# Altium schematic sheet superware_V1.0.SchDoc
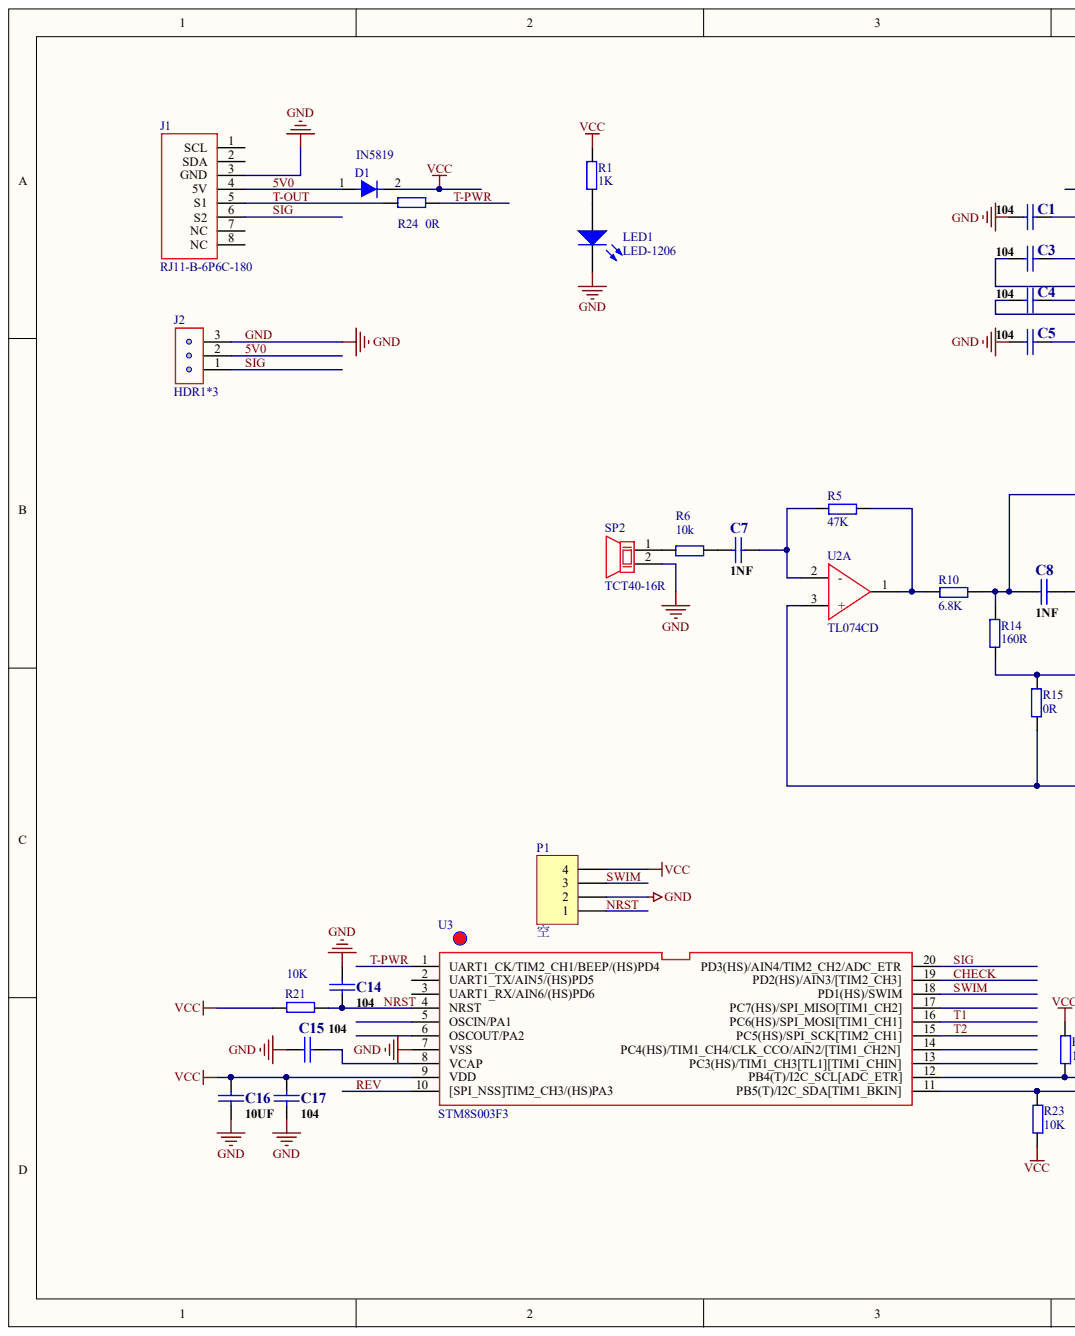
[diagram p0: superware_V1.0.SchDoc, left]
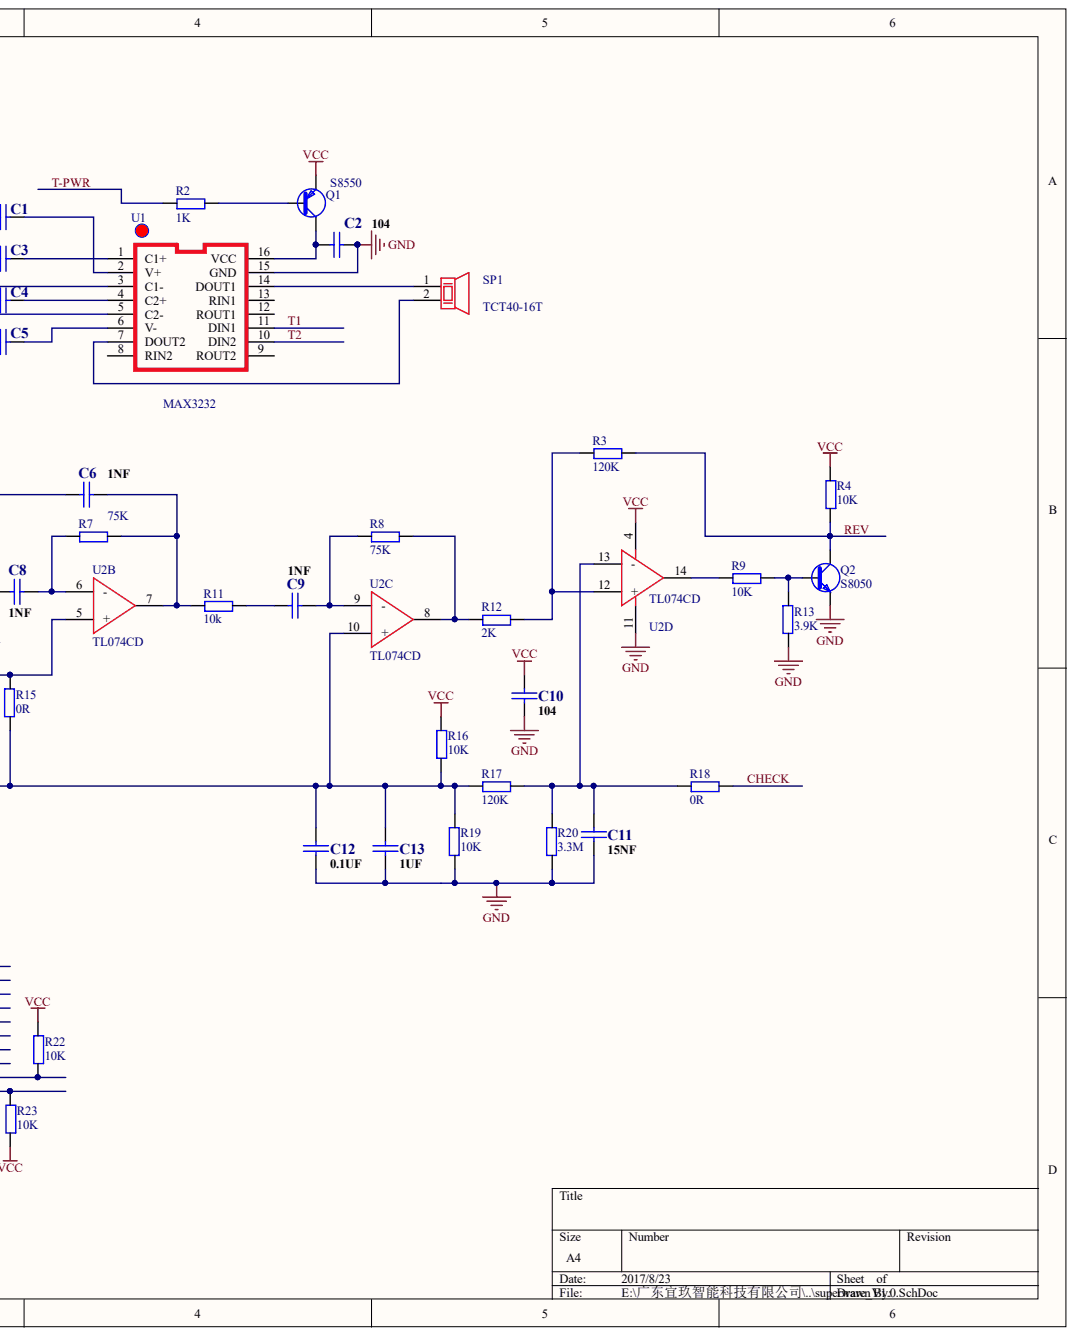
[diagram p1: superware_V1.0.SchDoc, right]

## Parts
C1  104  [0805]
C2  104  [0805]
C3  104  [0805]
C4  104  [0805]
C5  104  [0805]
C6  1NF  [0805]
C7  1NF  [0805]
C8  1NF  [0805]
C9  1NF  [0805]
C10  104  [0805]
C11  15NF  [0805]
C12  0.1UF  [0805]
C13  1UF  [0805]
C14  104  [0805]
C15  104  [0805]
C16  10UF  [0805]
C17  104  [0805]
D1  IN5819  [DO-214AC]
J1  RJ11-B-6P6C-180  [RJ11-B_6P6C_180]
J2  HDR1*3  [HDR1X3]
LED1  LED-1206  [LED-1206]
P1  空  [SMB1X4]
Q1  S8550  [SOT-23]
Q2  S8050  [SOT-23]
R1  1K  [0805]
R2  1K  [0805]
R3  120K  [0805]
R4  10K  [0805]
R5  47K  [0805]
R6  10k  [0805]
R7  75K  [0805]
R8  75K  [0805]
R9  10K  [0805]
R10  6.8K  [0805]
R11  10k  [0805]
R12  2K  [0805]
R13  3.9K  [0805]
R14  160R  [0805]
R15  0R  [0805]
R16  10K  [0805]
R17  120K  [0805]
R18  0R  [0805]
R19  10K  [0805]
R20  3.3M  [0805]
R21  10K  [0805]
R22  10K  [0805]
R23  10K  [0805]
R24  0R  [0805]
SP1  TCT40-16T  [TCT40-16R]
SP2  TCT40-16R  [TCT40-16R]
U1  MAX3232  [SOP-16]
U2  TL074CD  [SOP-14]  (parts A,B,C,D)
U3  STM8S003F3  [TSSOP20]

## Nets
VCC: C10.1 C16.1 C17.1 D1.2 P1.4 Q1.2 R1.1 R4.2 R16.2 R21.2 R22.2 R23.1 U2.4 U3.9(VDD)
5V0: D1.1 J1.4(5V) J2.2
CHECK: R18.2 U3.19(PD2(HS)/AIN3/[TIM2_CH3])
GND: C1.2 C2.2 C5.2 C10.2 C11.2 C12.2 C13.2 C14.2 C15.2 C16.2 C17.2 J1.3(GND) J2.3 LED1.2 P1.2 Q2.2 R13.2 R19.2 R20.2 SP2.2 U1.15(GND) U2.11(+) U3.7(VSS)
NRST: C14.1 P1.1 R21.1 U3.4(NRST)
REV: Q2.3 R3.2 R4.1 U3.10([SPI_NSS]TIM2_CH3/(HS)PA3)
SIG: J1.6(S2) J2.1 U3.20(PD3(HS)/AIN4/TIM2_CH2/ADC_ETR)
SWIM: P1.3 U3.18(PD1(HS)/SWIM)
T1: U1.11(DIN1) U3.16(PC6(HS)/SPI_MOSI[TIM1_CH1])
T2: U1.10(DIN2) U3.15(PC5(HS)/SPI_SCK[TIM2_CH1])
T-OUT: J1.5(S1) R24.2
T-PWR: R2.1 R24.1 U3.1(UART1_CK/TIM2_CH1/BEEP/(HS)PD4)
NetC1_1: C1.1 U1.2(V+)
NetC2_1: C2.1 Q1.3 U1.16(VCC)
NetC3_1: C3.1 U1.1(C1+)
NetC3_2: C3.2 U1.3(C1-)
NetC4_1: C4.1 U1.4(C2+)
NetC4_2: C4.2 U1.5(C2-)
NetC5_1: C5.1 U1.6(V-)
NetC6_1: C6.1 R7.2 R11.1 U2.7
NetC6_2: C6.2 C8.1 R10.2 R14.1
NetC7_1: C7.1 R6.2
NetC7_2: C7.2 R5.1 U2.2(-)
NetC8_2: C8.2 R7.1 U2.6(-)
NetC9_1: C9.1 R11.2
NetC9_2: C9.2 R8.1 U2.9(-)
NetC11_1: C11.1 R17.2 R18.1 R20.1 U2.13(-)
NetC12_1: C12.1 C13.1 R15.2 R16.1 R17.1 R19.1 U2.3(+) U2.10(+)
NetC15_1: C15.1 U3.8(VCAP)
NetLED1_1: LED1.1 R1.2
NetQ1_1: Q1.1 R2.2
NetQ2_1: Q2.1 R9.2 R13.1
NetR3_1: R3.1 R12.2 U2.12
NetR5_2: R5.2 R10.1 U2.1
NetR6_1: R6.1 SP2.1
NetR8_2: R8.2 R12.1 U2.8
NetR9_1: R9.1 U2.14
NetR14_2: R14.2 R15.1 U2.5(+)
NetR22_1: R22.1 U3.12(PB4(T)/I2C_SCL[ADC_ETR])
NetR23_2: R23.2 U3.11(PB5(T)/I2C_SDA[TIM1_BKIN])
NetSP1_1: SP1.1 U1.14(DOUT1)
NetSP1_2: SP1.2 U1.7(DOUT2)

Not connected: J1 1(SCL) 2(SDA) 7(NC) 8(NC); U1 8(RIN2) 9(ROUT2) 12(ROUT1) 13(RIN1); U3 2(UART1_TX/AIN5/(HS)PD5) 3(UART1_RX/AIN6/(HS)PD6) 5(OSCIN/PA1) 6(OSCOUT/PA2) 13(PC3(HS)/TIM1_CH3[TL1][TIM1_CHIN]) 14(PC4(HS)/TIM1_CH4/CLK_CCO/AIN2/[TIM1_CH2N]) 17(PC7(HS)/SPI_MISO[TIM1_CH2])

## Library data of the parts
C1-C17 = CAP: Capacitor; Component Kind: Standard; Library Name: DQSCH.SCHLIB
P1 = Header 4: Header, 4-Pin; Component Kind: Standard; LatestRevisionDate: 17-Jul-2002; LatestRevisionNote: Re-released for DXP Platform.; Publisher: Altium Limited
Q1 = PNP: Component Kind: Standard; Library Name: DQSCH.SCHLIB
Q2 = NPN: Component Kind: Standard; Library Name: DQSCH.SCHLIB
R1-R24 = R 0805: Component Kind: Standard; Library Name: DQSCH.SCHLIB
SP1 = TCT40-16R: Component Kind: Standard; Library Name: DQSCH.SCHLIB
U2 = LM324: Component Kind: Standard; Library Name: DQSCH.SCHLIB
D1 = IN5819: Component Kind: Standard; Library Name: DQSCH.SCHLIB
J1 = RJ11-B-6P6C-180: Component Kind: Standard; Library Name: DQSCH.SCHLIB
J2 = HDR1*3: Component Kind: Standard; Library Name: DQSCH.SCHLIB
LED1 = LED-1206: Component Kind: Standard; Library Name: DQSCH.SCHLIB
SP2 = TCT40-16R: Component Kind: Standard; Library Name: DQSCH.SCHLIB
U1 = MAX3232: Component Kind: Standard; Library Name: DQSCH.SCHLIB
U3 = STM8S003F3: Component Kind: Standard; Library Name: DQSCH.SCHLIB
